# Altium schematic sheet Sheet4.SchDoc(Sheet4)
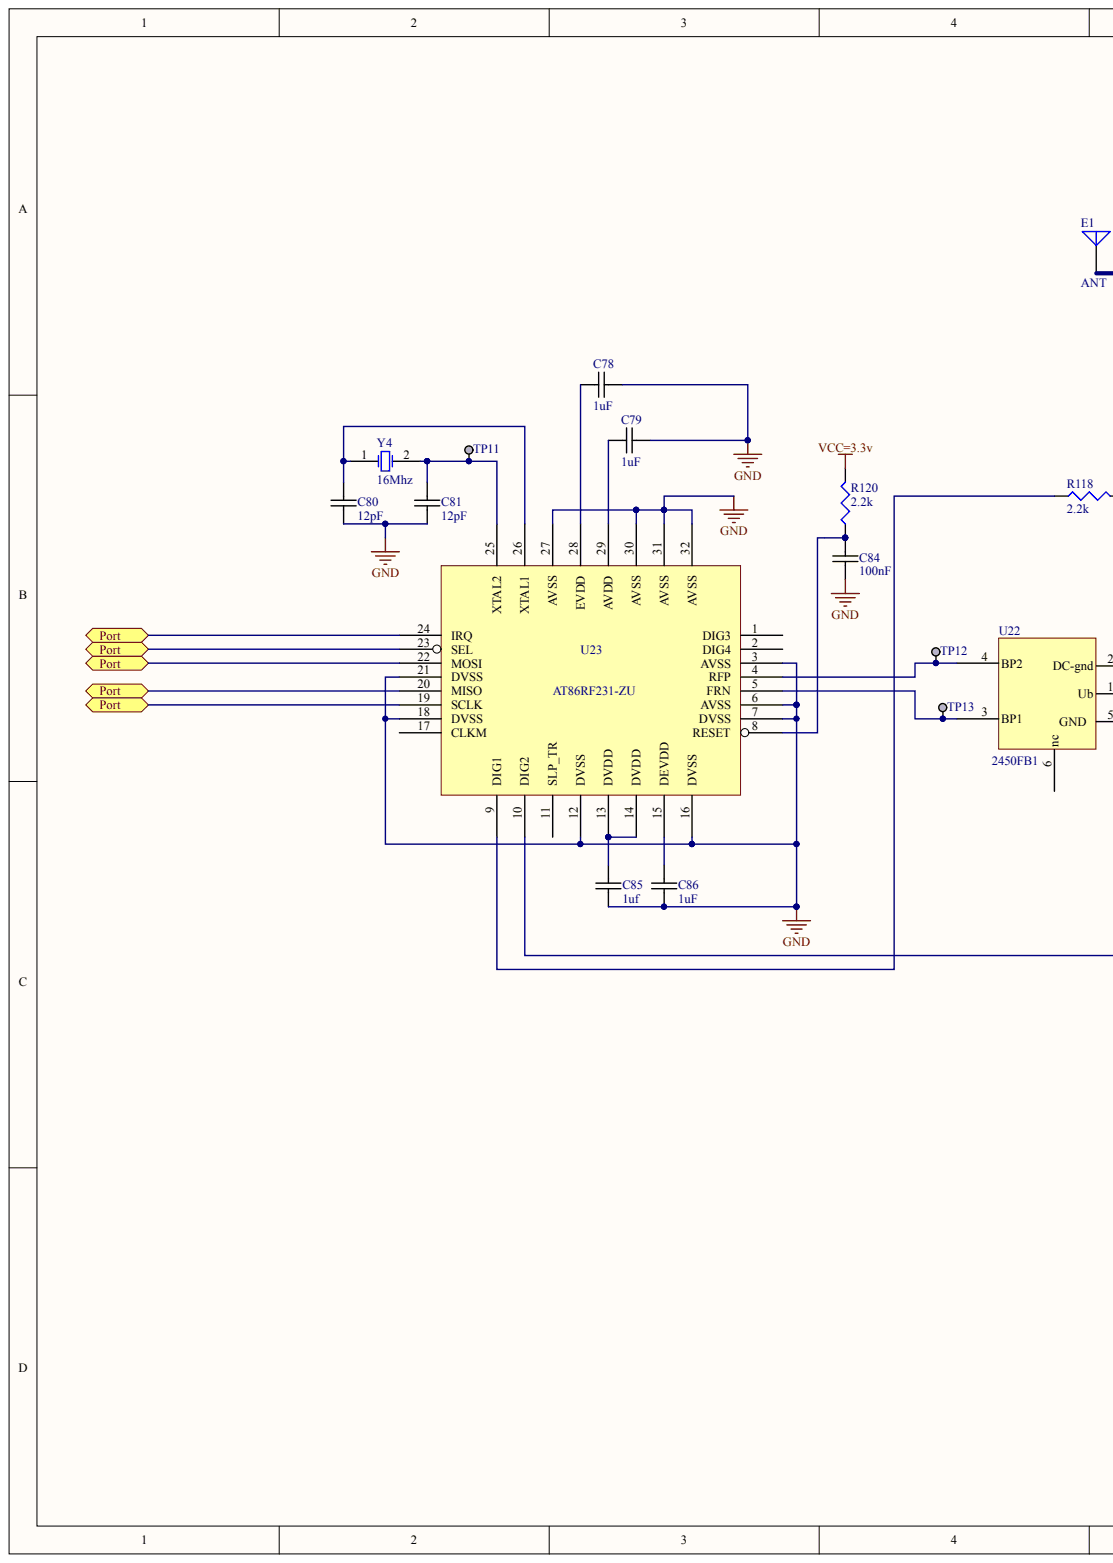
[diagram p0: Sheet4.SchDoc, left]
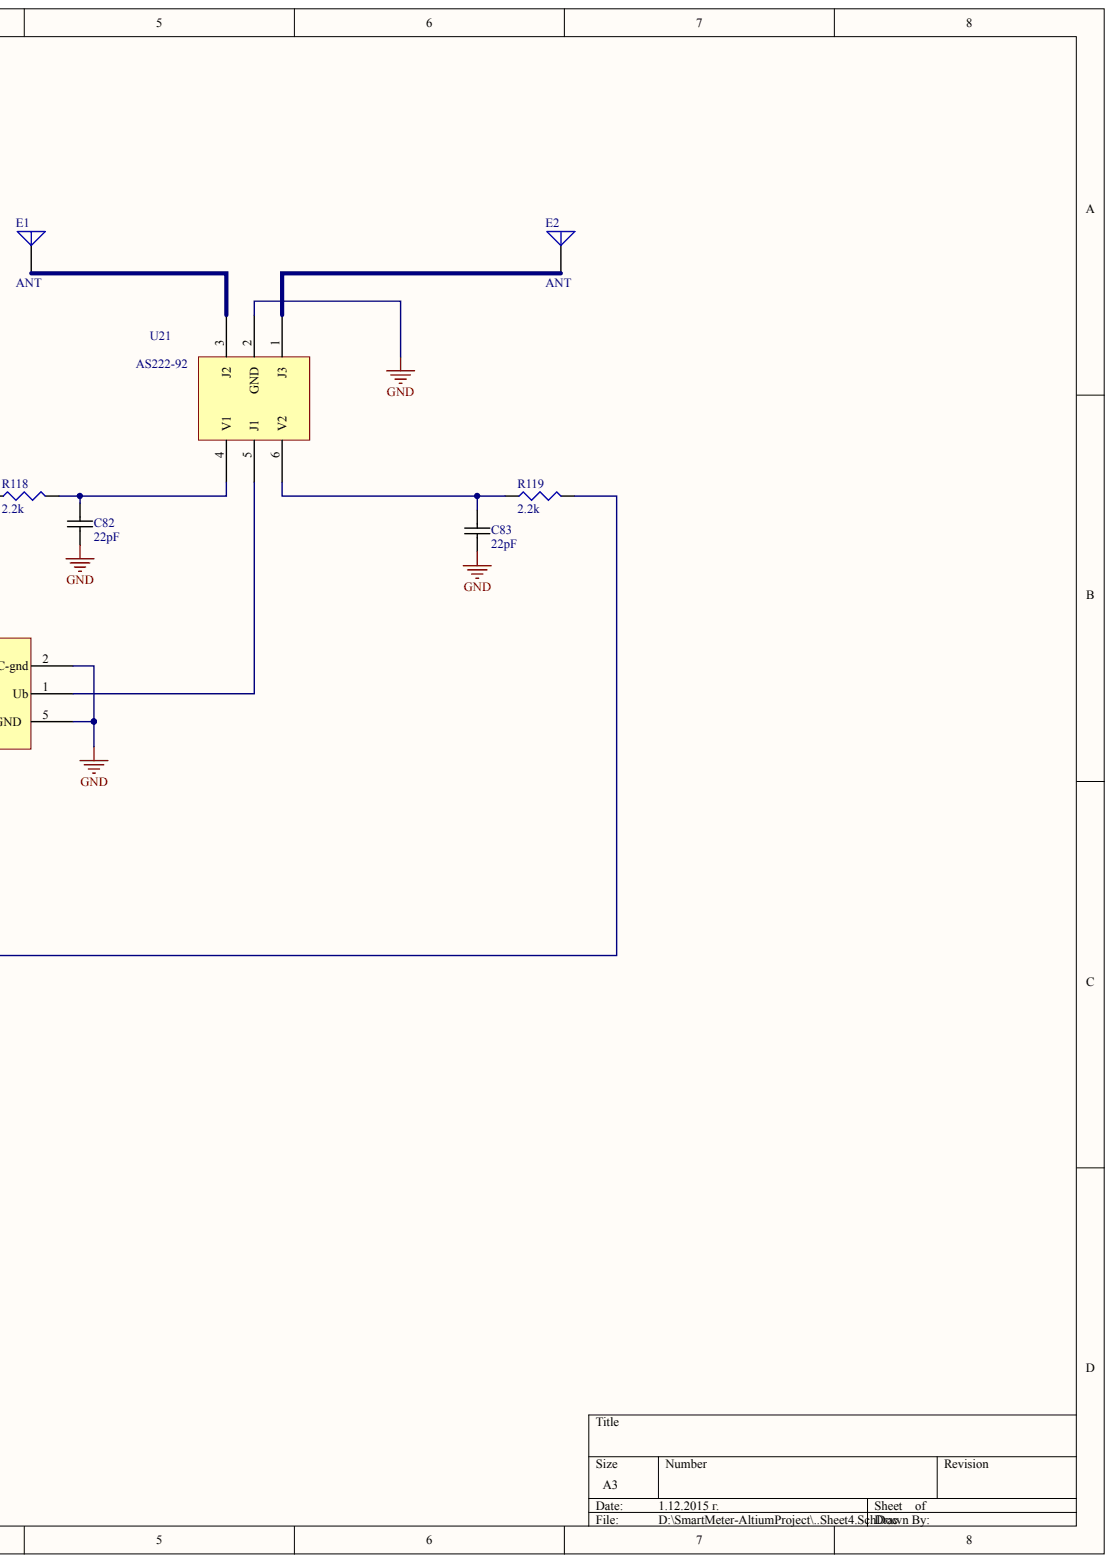
[diagram p1: Sheet4.SchDoc, right]

## Parts
C78  1uF
C79  1uF
C80  12pF
C81  12pF
C82  22pF
C83  22pF
C84  100nF
C85  1uf
C86  1uF
E1  ANT
E2  ANT
R118  2.2k
R119  2.2k
R120  2.2k
TP11  TESTPOINT  (value not drawn)
TP12  TESTPOINT  (value not drawn)
TP13  TESTPOINT  (value not drawn)
U21  AS222-92
U22  2450FB1
U23  AT86RF231-ZU
Y4  16Mhz

## Ports
PORT

## Nets
GND: C78.1 C79.2 C80.2 C81.2 C82.1 C83.1 C84.1 C85.1 C86.1 U21.2(GND) U22.2(DC-gnd) U22.5(GND) U23.3(AVSS) U23.6(AVSS) U23.7(DVSS) U23.12(DVSS) U23.16(DVSS) U23.18(DVSS) U23.21(DVSS) U23.27(AVSS) U23.30(AVSS) U23.31(AVSS) U23.32(AVSS)
VCC=3.3V: R120.2
NetC78_2: C78.2 U23.28(EVDD)
NetC79_1: C79.1 U23.29(AVDD)
NetC80_1: C80.1 U23.26(XTAL1) Y4.1
NetC81_1: C81.1 TP11.1 U23.25(XTAL2) Y4.2
NetC82_2: C82.2 R118.2 U21.4(V1)
NetC83_2: C83.2 R119.1 U21.6(V2)
NetC84_2: C84.2 R120.1 U23.8(RESET)
NetC85_2: C85.2 U23.13(DVDD) U23.14(DVDD)
NetC86_2: C86.2 U23.15(DEVDD)
NetE1_1: E1.1 U21.3(J2)
NetE2_1: E2.1 U21.1(J3)
NetR118_1: R118.1 U23.9(DIG1)
NetR119_2: R119.2 U23.10(DIG2)
NetTP12_1: TP12.1 U22.4(BP2) U23.4(RFP)
NetTP13_1: TP13.1 U22.3(BP1) U23.5(FRN)
NetU21_5: U21.5(J1) U22.1(Ub)
NetU23_19: U23.19(SCLK) U23.20(MISO) U23.22(MOSI) U23.23(SEL) U23.24(IRQ) ; port PORT

Not connected: U22 6(nc); U23 1(DIG3) 2(DIG4) 11(SLP_TR) 17(CLKM)

## Library data of the parts
C83 = (no symbol name): Value: 10n; Supplier 1: Digi-Key; Supplier Part Number 1: 709-1171-2-ND; mfr PN: *; Package Reference: 0805-TBD
C86 = (no symbol name): Value: 22pF; Supplier 1: Digi-Key; Supplier Part Number 1: 709-1171-2-ND; mfr PN: *; Package Reference: 0805-TBD
R118 R120 = (no symbol name): Value: 2.2k/.125W 1%; Published: 8-Jun-2000; LatestRevisionDate: 29-May-2009; LatestRevisionNote: IPC-7351 Footprint Added.; PackageReference: J1-0603; PackageDescription: Chip Resistor; Publisher: Altium Limited
R119 = (no symbol name): Value: 32.2k/.125W 1%; Published: 8-Jun-2000; LatestRevisionDate: 29-May-2009; LatestRevisionNote: IPC-7351 Footprint Added.; PackageReference: J1-0603; PackageDescription: Chip Resistor; Publisher: Altium Limited
TP11-TP13 = (no symbol name): Manufacturer Part Number: 5010; Manufacturer: Keystone Electronics; Reel: TH; Supplier 1: Digi-Key; Supplier Part Number 1: 5010K-ND
C78-C82 C84 C85 E1 E2 U21-U23 Y4 = (no symbol name)
  C78 C79 C85: Supplier 1: Digi-Key; Supplier Part Number 1: 709-1171-2-ND; Value: 1uF; mfr PN: *; Package Reference: 0805-TBD
  C80 C81: Supplier 1: Digi-Key; Supplier Part Number 1: 709-1171-2-ND
  C82: Supplier 1: Digi-Key; Supplier Part Number 1: 709-1171-2-ND; Value: 22pF; mfr PN: *; Package Reference: 0805-TBD
  C84: Supplier 1: Digi-Key; Supplier Part Number 1: 709-1171-2-ND; Value: 100nF; mfr PN: *; Package Reference: 0805-TBD
  E1 E2: Published: 24-Mar-1999; LatestRevisionDate: 17-Jul-2002; LatestRevisionNote: Re-released for DXP Platform.; PackageReference: PIN1
  U23: Published: 20-Sep-2010; DatasheetVersion: revC, Sep-2009; PackageVersion: revA, Nov-2007; PackageReference: 32QN2; PackageDescription: 32-Pin Quad Flat No-Lead (QFN) Sawn,  5 x 5 x 1.0 mm Body, Pitch 0.50 mm, 3.10mm Exposed Pad; Supplier 1: Digi-Key; Supplier Part Number 1: AT86RF231-ZU-ND; Supplier 2: Farnell; Supplier Part Number 2: 1748493; Supplier 3: Mouser; Supplier Part Number 3: 556-AT86RF231-ZU; Code_JEDEC: MO-220VHHD-6; ComponentLink1Description: AT86RF231 Datasheet; ComponentLink1URL: http://www.atmel.com/dyn/resources/prod_documents/doc8111.pdf; ComponentLink2Description: Atmel.com Home; ComponentLink2URL: http://www.atmel.com
  Y4: Published: 8-Jun-2000; LatestRevisionDate: 17-Jul-2002; LatestRevisionNote: Re-released for DXP Platform.; PackageReference: R38; PackageDescription: Crystal, Thru-Hole; 2 Leads; Body 3.1 x 8.2 mm (Dia.xH); Value: 16MHz
  E1 E2 U23 Y4: Publisher: Altium Limited
